# Altium schematic sheet 2_Sensors.SchDoc
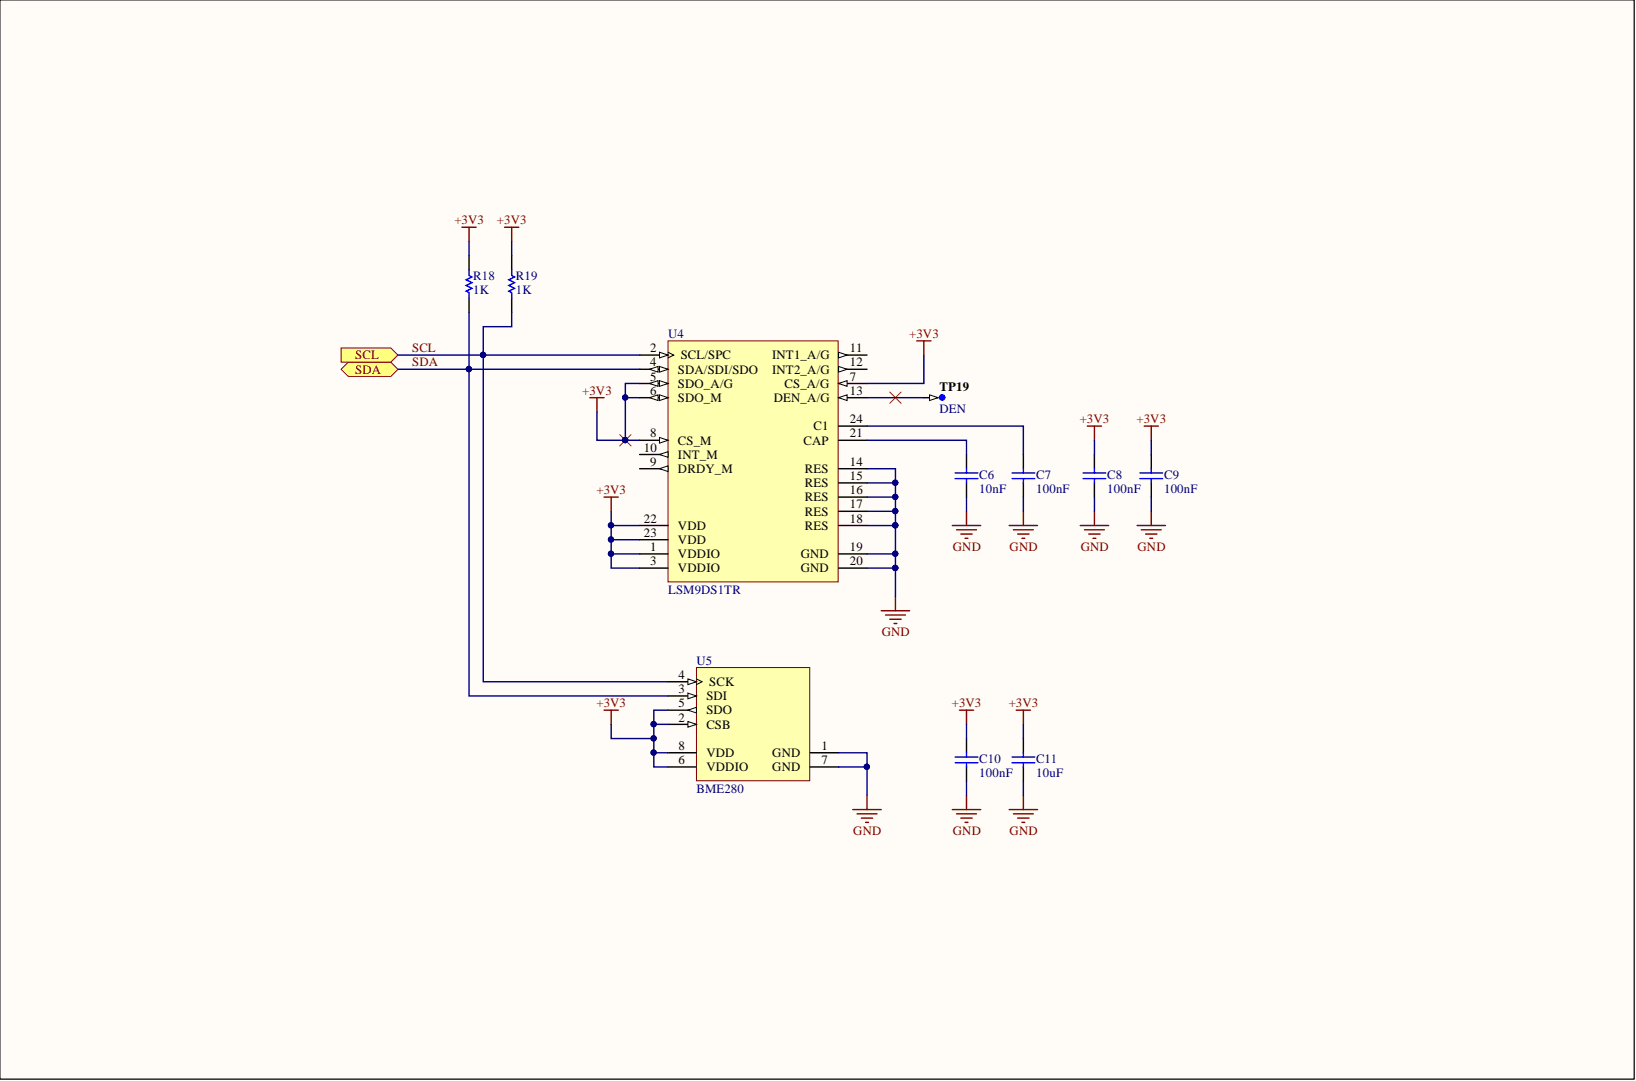
[diagram p0: 2_Sensors.SchDoc]

## Parts
C6  10nF  [CAPC0603(1608)100_M]
C7  100nF  [CAPC0603(1608)100_M]
C8  100nF  [CAPC0603(1608)100_M]
C9  100nF  [CAPC0603(1608)100_M]
C10  100nF  [CAPC0603(1608)100_M]
C11  10uF  [CAPC1206(3216)130_L]
R18  1K  [RESC0603(1608)_M]
R19  1K  [RESC0603(1608)_M]
TP19  DEN  [TP-SM-C-100]  (no BOM)
U4  LSM9DS1TR  [STM-LGA-24_3500X3000X1000]
U5  BME280  [BOSC-BME280-8_V]

## Ports
SCL, SDA

## Nets
+3V3: C8.1 C9.1 C10.1 C11.2 R18.2 R19.2 U4.1(VDDIO) U4.3(VDDIO) U4.5(SDO_A/G) U4.6(SDO_M) U4.7(CS_A/G) U4.8(CS_M) U4.22(VDD) U4.23(VDD) U5.2(CSB) U5.5(SDO) U5.6(VDDIO) U5.8(VDD)
GND: C6.1 C7.2 C8.2 C9.2 C10.2 C11.1 U4.14(RES) U4.15(RES) U4.16(RES) U4.17(RES) U4.18(RES) U4.19(GND) U4.20(GND) U5.1(GND) U5.7(GND)
SCL: R19.1 U4.2(SCL/SPC) U5.4(SCK) ; port SCL
SDA: R18.1 U4.4(SDA/SDI/SDO) U5.3(SDI) ; port SDA
NetC6_2: C6.2 U4.21(CAP)
NetC7_1: C7.1 U4.24(C1)
NetTP19_1: TP19.1 U4.13(DEN_A/G)

Not connected: U4 9(DRDY_M) 10(INT_M) 11(INT1_A/G) 12(INT2_A/G)

## Library data of the parts
C6 = CMP-1035-02316-1: CAP 10nF 25V ±5% 0603 (1608 Metric) Thickness 1mm SMD; Case-EIA: 0603; Case-Metric: 1608; Tolerance: Â±5%; Component Kind: Standard; Library Name: Altium Content Vault; Max Thickness: 1 mm; Rated Voltage: 25 V; Technology: SMT; Value: 10nF
C7-C10 = CMP-1035-02589-1: CAP 100nF 25V ±20% 0603 (1608 Metric) Thickness 1mm SMD; Case-EIA: 0603; Case-Metric: 1608; Tolerance: Â±20%; Component Kind: Standard; Library Name: Altium Content Vault; Max Thickness: 1 mm; Rated Voltage: 25 V; Technology: SMT; Value: 100nF
C11 = CMP-1037-04977-1: CAP 10uF 16V -20% to +80% 1206 (3216 Metric) Thickness 1.3mm SMD; Case-EIA: 1206; Case-Metric: 3216; Component Kind: Standard; Library Name: Altium Content Vault; Max Thickness: 1.3 mm; Rated Voltage: 16 V; Technology: SMT; Tolerance: -20% to +80%; Value: 10uF
R18 R19 = CMP-1012-00510-1: 1K 0.1W 1% 0603 (1608 Metric)  SMD; Case-EIA: 0603; Case-Metric: 1608; Value: 1000.00 Ohm; Component Kind: Standard; Library Name: Altium Content Vault; Power: 0.1 W; Technology: SMT; Tolerance: 1 %
TP19 = CMP-0484-00002-2: Test Point, Surface Mount, Circular, Pad Diameter 1 mm; Manufacturer: No Vendor; Library Name: Altium Content Vault; PartNumber: TP-SM-C-100
U4 = CMP-2000-06606-1: iNEMO Inertial Module: 3D Accelerometer, 3D Gyroscope, 3D Magnetometer, 1.9 to 3.6 V, -40 to 85 degC, 24-Pin LGA, RoHS, Tape and Reel; Manufacturer: STMicroelectronics; Acceleration Range: ±2g,±4g,±8g,±16g; Gyroscope Range: ±245°/s,±500°/s,±2000°/s; MEMS Module Function: Tri-AxisGyroscope,Tri-AxisAccelerometer,Tri-AxisMagnetometer; No. of Pins: 24Pins; Supply Voltage Max: 3.6V; Supply Voltage Min: 1.9V; SVHC: NoSVHC(17-Dec-2015); Component Kind: Standard; Datasheet URL: http://www2.st.com/content/ccc/resource/technical/document/datasheet/1e/3f/2a/d6/25/eb/48/46/DM00103319.pdf/files/DM00103319.pdf/jcr:content/translations/en.DM00103319.pdf; Datasheet Version: Rev. 3, 03/2015; Library Name: Altium Content Vault; Manufacturer URL: http://www.st.com/; Mounting Technology: SurfaceMount; MSL: MSL3-168hours; Package Description: 24-Pin Plastic Land Grid Array Package, Body 3.65 x 3.15 mm, Pitch 0.43 mm; Package Reference: LGA-24_3500X3000X1000; Packaging: CutTape; PartNumber: LSM9DS1; Product Range: -; Sensor Case Style: LGA
U5 = CMP-2000-05073-1: Combined Humidity and Pressure Sensor, 1.71 to 3.6 V, -40 to 85 degC, 8-Pin SMD, RoHS, Tape and Reel; Manufacturer: Bosch Sensortec; Component Kind: Standard; Datasheet URL: http://datasheet.octopart.com/BME280-Bosch-datasheet-58577763.pdf; Datasheet Version: Rev. 1.2, 10/2015; Library Name: Altium Content Vault; Manufacturer URL: http://www.bosch-sensortec.com
